# Altium schematic sheet Powersupply.SchDoc
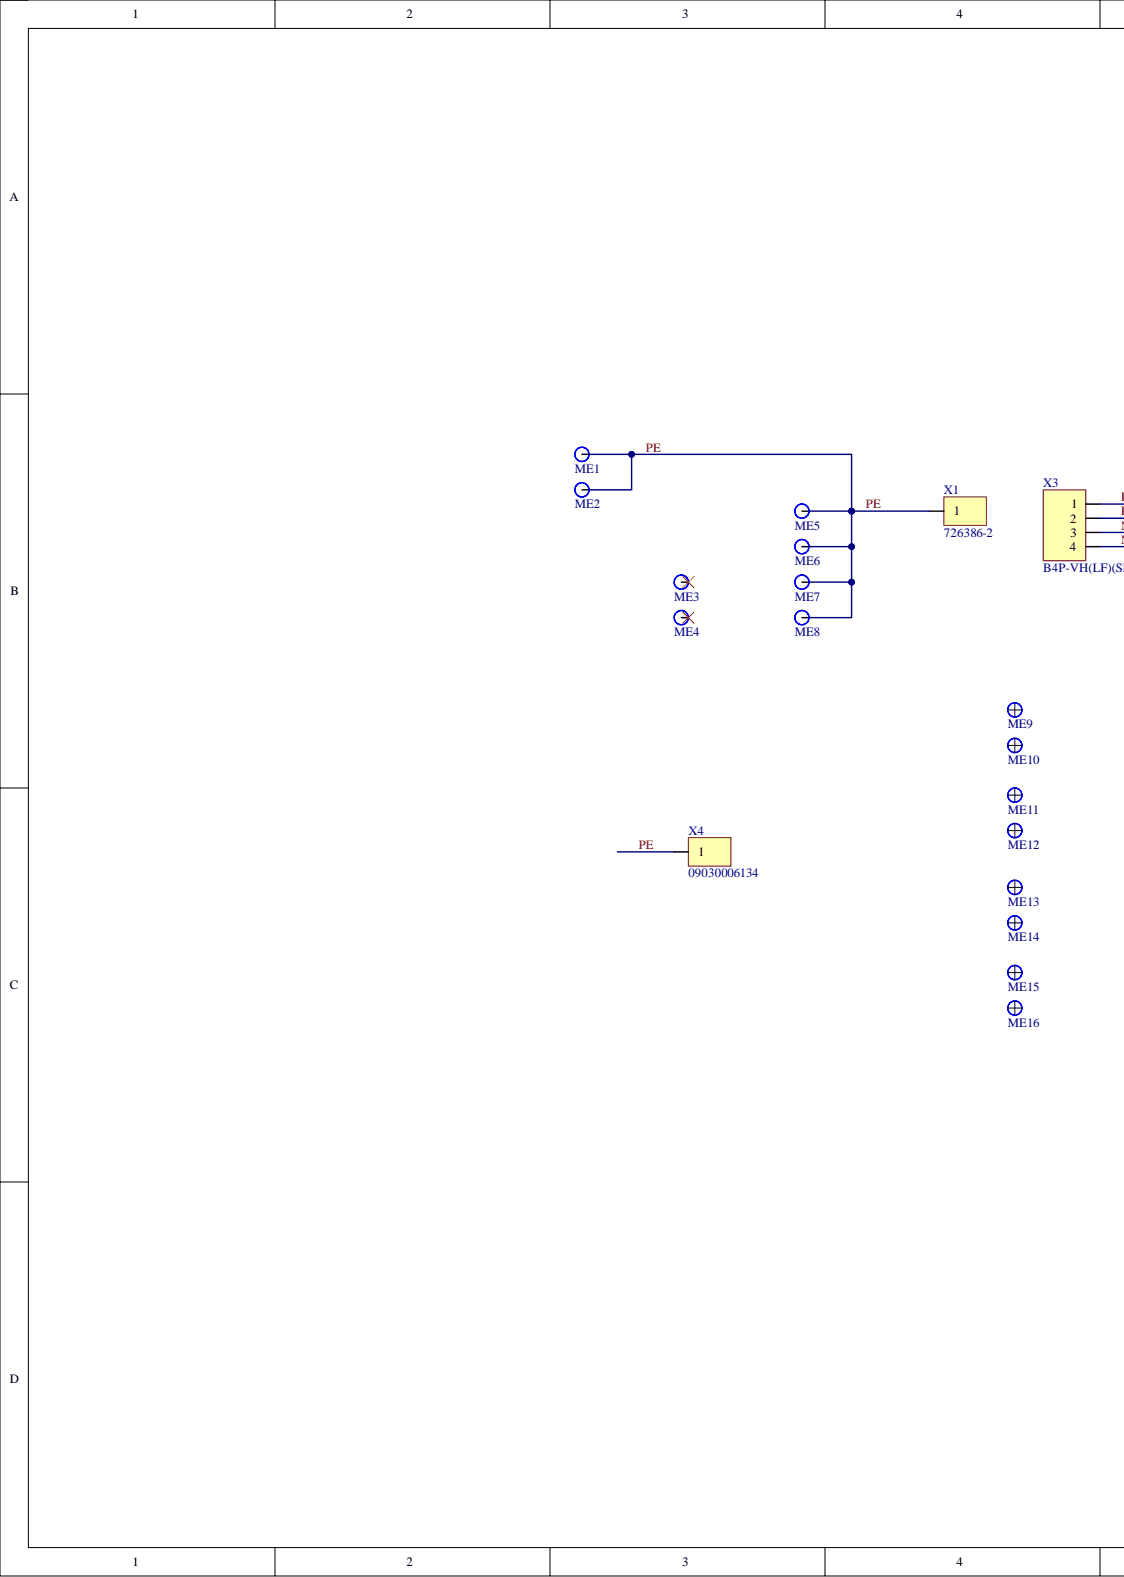
[diagram p0: Powersupply.SchDoc, left]
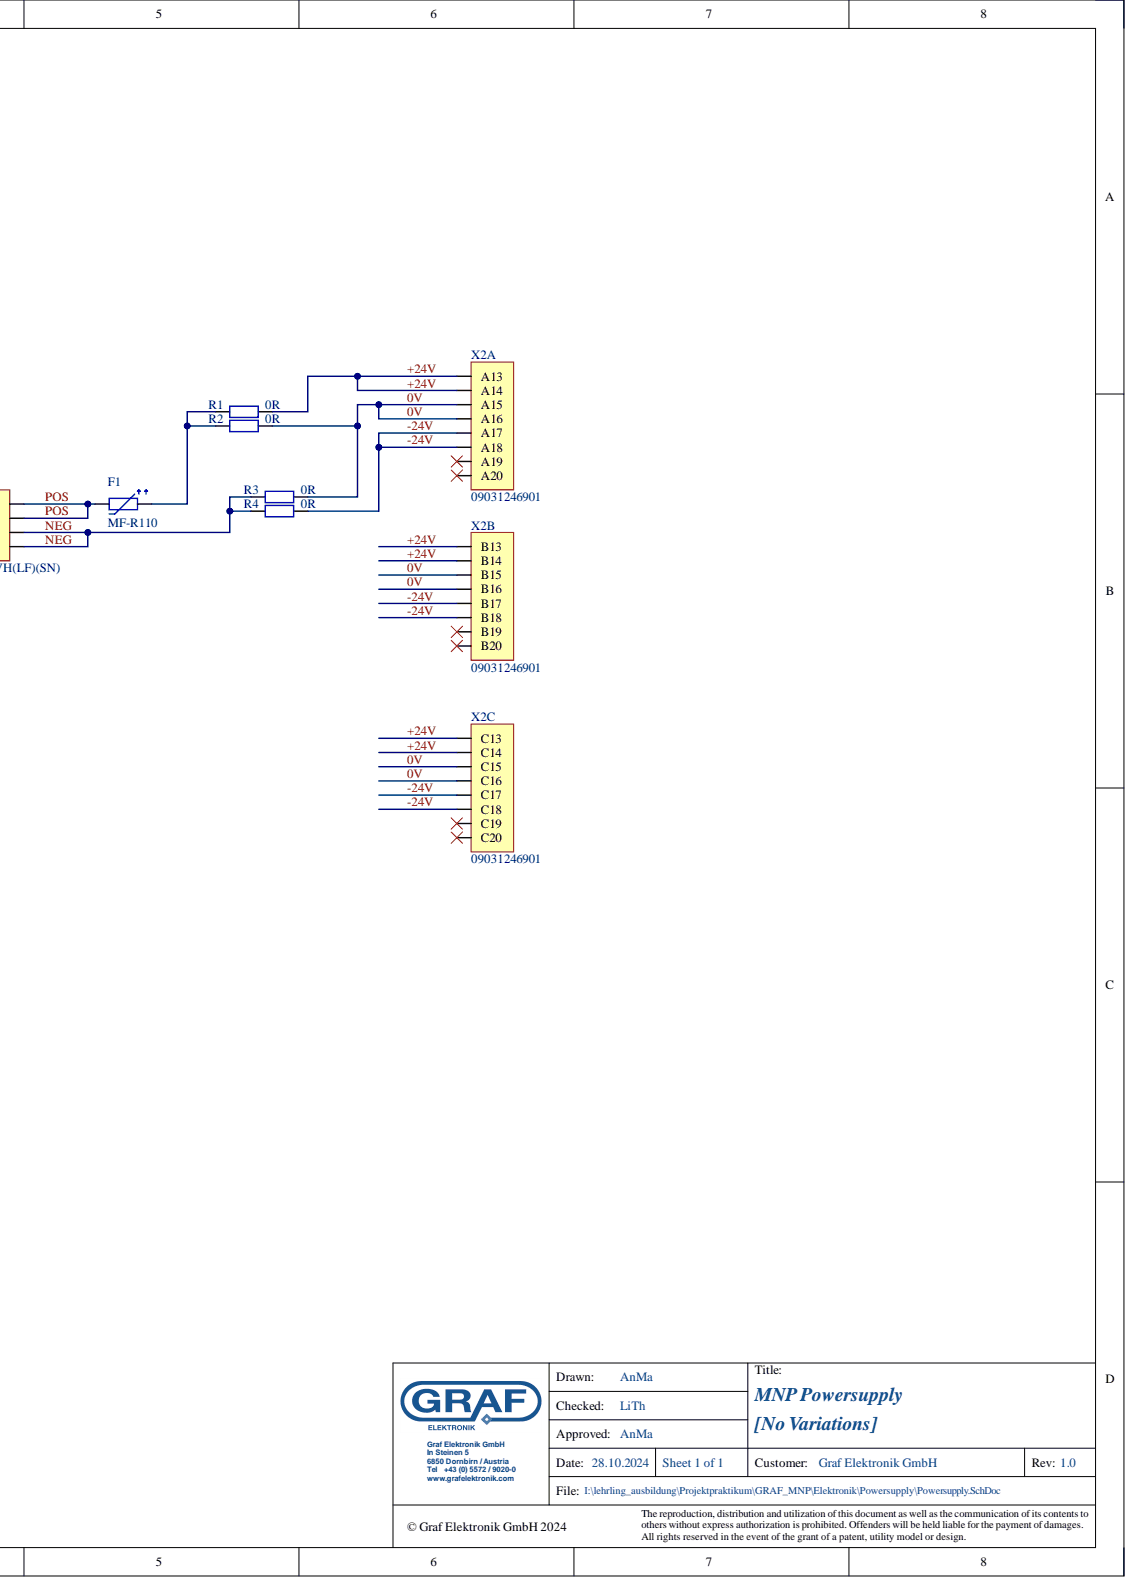
[diagram p1: Powersupply.SchDoc, right]

## Parts
F1  Manufacturer Part Number 1: MF-R110  [FUSE_BOURNS-MF-R110]  (hidden comment: PAMFR110-K42)
ME1  AD-HOLE-M3.0_B  [HOLE-M3-MEDIUM-PAD8.2MM]  (value not drawn)  (no BOM)
ME2  AD-HOLE-M3.0_B  [HOLE-M3-MEDIUM-PAD8.2MM]  (value not drawn)  (no BOM)
ME3  AD-HOLE-M3.0_B  [HOLE-M3-MEDIUM-PAD8.2MM]  (value not drawn)  (no BOM)
ME4  AD-HOLE-M3.0_B  [HOLE-M3-MEDIUM-PAD8.2MM]  (value not drawn)  (no BOM)
ME5  AD-HOLE-M3.0_B  [HOLE-M3-MEDIUM-PAD8.2MM]  (value not drawn)  (no BOM)
ME6  AD-HOLE-M3.0_B  [HOLE-M3-MEDIUM-PAD8.2MM]  (value not drawn)  (no BOM)
ME7  AD-HOLE-M3.0_B  [HOLE-M3-MEDIUM-PAD8.2MM]  (value not drawn)  (no BOM)
ME8  AD-HOLE-M3.0_B  [HOLE-M3-MEDIUM-PAD8.2MM]  (value not drawn)  (no BOM)
ME9  AD-HOLE-M4.0-NO-CU  [HOLE-M4-NO-CU-FINE]  (value not drawn)  (no BOM)
ME10  AD-HOLE-M4.0-NO-CU  [HOLE-M4-NO-CU-FINE]  (value not drawn)  (no BOM)
ME11  AD-HOLE-M4.0-NO-CU  [HOLE-M4-NO-CU-FINE]  (value not drawn)  (no BOM)
ME12  AD-HOLE-M4.0-NO-CU  [HOLE-M4-NO-CU-FINE]  (value not drawn)  (no BOM)
ME13  AD-HOLE-M4.0-NO-CU  [HOLE-M4-NO-CU-FINE]  (value not drawn)  (no BOM)
ME14  AD-HOLE-M4.0-NO-CU  [HOLE-M4-NO-CU-FINE]  (value not drawn)  (no BOM)
ME15  AD-HOLE-M4.0-NO-CU  [HOLE-M4-NO-CU-FINE]  (value not drawn)  (no BOM)
ME16  AD-HOLE-M4.0-NO-CU  [HOLE-M4-NO-CU-FINE]  (value not drawn)  (no BOM)
R1  ValueA: 0R  [RESAD1000W55L630D240B]  (hidden comment: WI000R-S10)
R2  ValueA: 0R  [RESAD1000W55L630D240B]  (hidden comment: WI000R-S10)
R3  ValueA: 0R  [RESAD1000W55L630D240B]  (hidden comment: WI000R-S10)
R4  ValueA: 0R  [RESAD1000W55L630D240B]  (hidden comment: WI000R-S10)
X1  Manufacturer Part Number 1: 726386-2  [TE_726386-X]  (hidden comment: STG726386-K01)
X2  Manufacturer Part Number 1: 09031246901  [HARTING_09031246901]  (hidden comment: ST09031246901-K00)  (parts A,B,C)
X3  Manufacturer Part Number 1: B4P-VH(LF)(SN)  [JST_B4P-VH]  (hidden comment: STGB4P-VH-K30)
X4  Manufacturer Part Number 1: 09030006134  [HARTING_09030006134]  (hidden comment: ST09030006134-K00)

## Nets
0V: R2.2 R3.2 X2.A15 X2.A16 X2.B15 X2.B16 X2.C15 X2.C16
+24V: R1.2 X2.A13 X2.A14 X2.B13 X2.B14 X2.C13 X2.C14
-24V: R4.2 X2.A17 X2.A18 X2.B17 X2.B18 X2.C17 X2.C18
NEG: R3.1 R4.1 X3.3 X3.4
PE: ME1.1 ME2.1 ME5.1 ME6.1 ME7.1 ME8.1 X1.1 X4.1
POS: F1.1 X3.1 X3.2
NetF1_2: F1.2 R1.1 R2.1

Not connected: ME3 1; ME4 1; X2 A19 A20 B19 B20 C19 C20

## Library data of the parts
F1 = PAMFR110-K42: Sicherung, MF-R110 (Bourns), 2 pol, Multifuse, 1,1A, RM5; CheckedByOn: lith/2015-12-22; CreatedByOn: gope/2015-12-14; Database Table Name: ViewAltiumLibrary; PG: K; PGO: 2; ValueB: 2 pol, 1,1A, Multifuse, RM5; ValueC: 1,1A; ArticleGroup: Sicherung; Component Kind: Standard; ComponentLink1Description: Datasheet1; ComponentLink1URL: A:\datasheets\PAMFR110-K42\BOURNS_MF-R110.pdf; HelpURL: A:\datasheets\PAMFR110-K42\BOURNS_MF-R110.pdf; Ident: PAMFR110-K42; Library Name: DatabaseLibrary.DbLib; LifecycleId: 1; Manufacturer 1: Bourns; ValueA: MF-R110
ME1-ME8 = AD-HOLE-M3.0_B: Befestigungsbohrung M3.0; ArticleGroup: Altium Designer Element; CheckedByOn: gope/2018-03-12; CreatedByOn: fith/2018-03-12; Database Table Name: ViewAltiumLibrary; PGO: 4; Ident: AD-HOLE-M3.0_B; Library Name: DatabaseLibrary.DbLib; LifecycleId: 1
ME9-ME16 = AD-HOLE-M4.0-NO-CU: Befestigungsbohrung M4.0, ohne Kupfer; ArticleGroup: Altium Designer Element; CheckedByOn: lith/2017-07-27; CreatedByOn: zuma/2017-07-26; Database Table Name: ViewAltiumLibrary; PGO: 4; Ident: AD-HOLE-M4.0-NO-CU; Library Name: DatabaseLibrary.DbLib; LifecycleId: 1
R1-R4 = WI000R-S10: Widerstand, MBB02070Z0000ZC100 (Vishay), axial, 0R, 1%, Metall, TK50; CheckedByOn: lith/2022-03-14; CreatedByOn: mach/2022-03-10; Database Table Name: ViewAltiumLibrary; PG: K; PGO: 2; ValueB: axial, 1%, TK50; ArticleGroup: Widerstand; Component Kind: Standard; ComponentLink1Description: Datasheet1; ComponentLink1URL: A:\datasheets\WI000R-S10\mbxsma.pdf; HelpURL: A:\datasheets\WI000R-S10\mbxsma.pdf; Ident: WI000R-S10; Library Name: DatabaseLibrary.DbLib; LifecycleId: 1; Manufacturer 1: Vishay; Manufacturer Part Number 1: MBB02070Z0000ZC100; ValueD: 1%; ValueE: axial; ValueF: TK50; ValueH: 0R
X1 = STG726386-K01: Steckverbinder, 726386-2 (TE Connectivity), 2 pol, Flachstecker; CheckedByOn: zuma/2019-02-14; CreatedByOn: fith/2019-02-12; Database Table Name: ViewAltiumLibrary; PG: K; PGO: 2; ArticleGroup: Steckverbinder; Component Kind: Standard; ComponentLink1Description: Datasheet1; ComponentLink1URL: A:\datasheets\STG726386-K01\TE_726386-2.pdf; ComponentLink2Description: Datasheet2; ComponentLink2URL: https://www.te.com/deu-de/product-726386-2.html?source=header-match; HelpURL: A:\datasheets\STG726386-K01\TE_726386-2.pdf; Ident: STG726386-K01; Library Name: DatabaseLibrary.DbLib; LifecycleId: 1; Manufacturer 1: TE Connectivity; ValueA: 726386-2; ValueB: Flachstecker
X2 = ST09031246901-K00: Steckverbinder, 09031246901 (HARTING Technology Group), 32 pol, 32 (24 + 8 Koax,) Positionen DIN-Steckverbinder gewinkelt, 24pol 3-reihig Lötpins M, -55°C bis 125°C CuZn, RM2,54, 20mR, 2,00A, 1000Vac, 1,00M, grau, 8 Spezialkontakte, 7,62 mm; CheckedByOn: zuma/2024-10-17; CreatedByOn: lith/2024-10-15; Database Table Name: ViewAltiumLibrary; PG: K; PGO: 2; ValueB: 32 (24 + 8 Koax,) Positionen DIN-Steckverbinder, gewinkelt, 24; ArticleGroup: Steckverbinder; Component Kind: Standard; ComponentLink1Description: Datasheet1; ComponentLink1URL: A:\datasheets\ST09031246901-K00\PDF_DS_09031246901_DE.pdf; ComponentLink2Description: Datasheet2; ComponentLink2URL: https://b2b.harting.com/ebusiness/de/DIN-Signal-M024MS-30C1-2/09031246901; ComponentLink3Description: Datasheet3; ComponentLink3URL: A:\datasheets\ST09031246901-K00\0903124X901_100072201DRW004C.pdf; HelpURL: A:\datasheets\ST09031246901-K00\PDF_DS_09031246901_DE.pdf; Ident: ST09031246901-K00; Library Name: DatabaseLibrary.DbLib; LifecycleId: 1; Manufacturer 1: HARTING Technology Group; ValueA: 09031246901
X3 = STGB4P-VH-K30: Steckverbinder, B4P-VH(LF)(SN) (JST), 4 pol, Top-Entry Stiftleiste (Wire-to-Board) gerade, 1x4pol M, -25°C bis 85°C, RM3,96; Manufacturer 1: J.S.T.MFG.CO.,LTD; CheckedByOn: lith/2018-01-15; CreatedByOn: mach/2018-01-11; Database Table Name: ViewAltiumLibrary; PG: K; PGO: 2; ULFileNumber: E60389; ValueB: Top-Entry Stiftleiste (Wire-to-Board), gerade, 1x4; ArticleGroup: Steckverbinder; Component Kind: Standard; ComponentLink1Description: Datasheet1; ComponentLink1URL: A:\datasheets\STGB4P-VH-K30\JST_B4P-VH(LF)(SN).pdf; ComponentLink2Description: Datasheet2; ComponentLink2URL: http://jst.de/product-family/show/231/vh; HelpURL: A:\datasheets\STGB4P-VH-K30\JST_B4P-VH(LF)(SN).pdf; Ident: STGB4P-VH-K30; Library Name: DatabaseLibrary.DbLib; LifecycleId: 1; ValueA: B4P-VH(LF)(SN)
X4 = ST09030006134-K00: Steckverbinder, 09030006134 (HARTING Technology Group), 4 pol, Kontaktpin gewinkelt, 1pol Lötpins M CuZn, 40,00A; CheckedByOn: zuma/2024-10-17; CreatedByOn: lith/2024-10-16; Database Table Name: ViewAltiumLibrary; PG: K; PGO: 2; ValueB: Kontaktpin, gewinkelt, 1; ArticleGroup: Steckverbinder; Component Kind: Standard; ComponentLink1Description: Datasheet1; ComponentLink1URL: A:\datasheets\ST09030006134-K00\PDF_DS_09030006134_DE.pdf; ComponentLink2Description: Datasheet2; ComponentLink2URL: https://b2b.harting.com/ebusiness/de/DIN-Signal-Hochstrom-m-40A-Loet-V/09030006134; ComponentLink3Description: Datasheet3; ComponentLink3URL: A:\datasheets\ST09030006134-K00\0903000X134_100072417DRW002C.pdf; HelpURL: A:\datasheets\ST09030006134-K00\PDF_DS_09030006134_DE.pdf; Ident: ST09030006134-K00; Library Name: DatabaseLibrary.DbLib; LifecycleId: 1; Manufacturer 1: HARTING Technology Group; ValueA: 09030006134
